# Altium schematic sheet 比较器方案.SchDoc
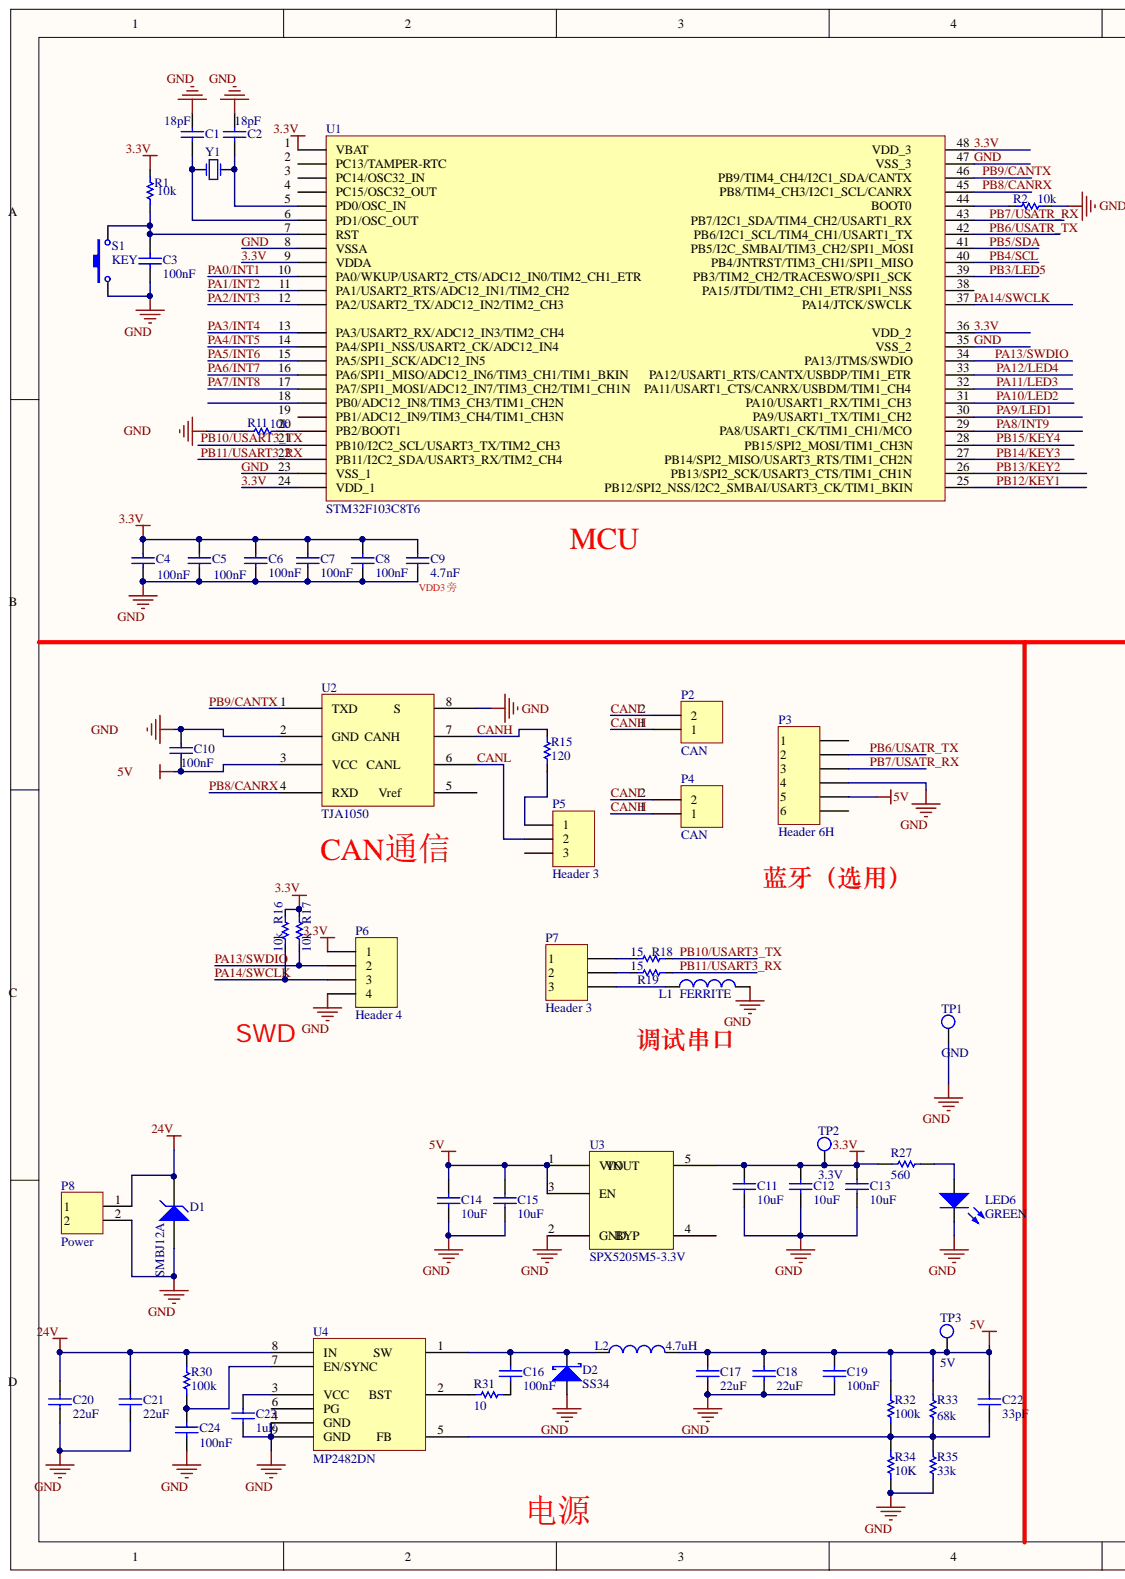
[diagram p0: 比较器方案.SchDoc, left]
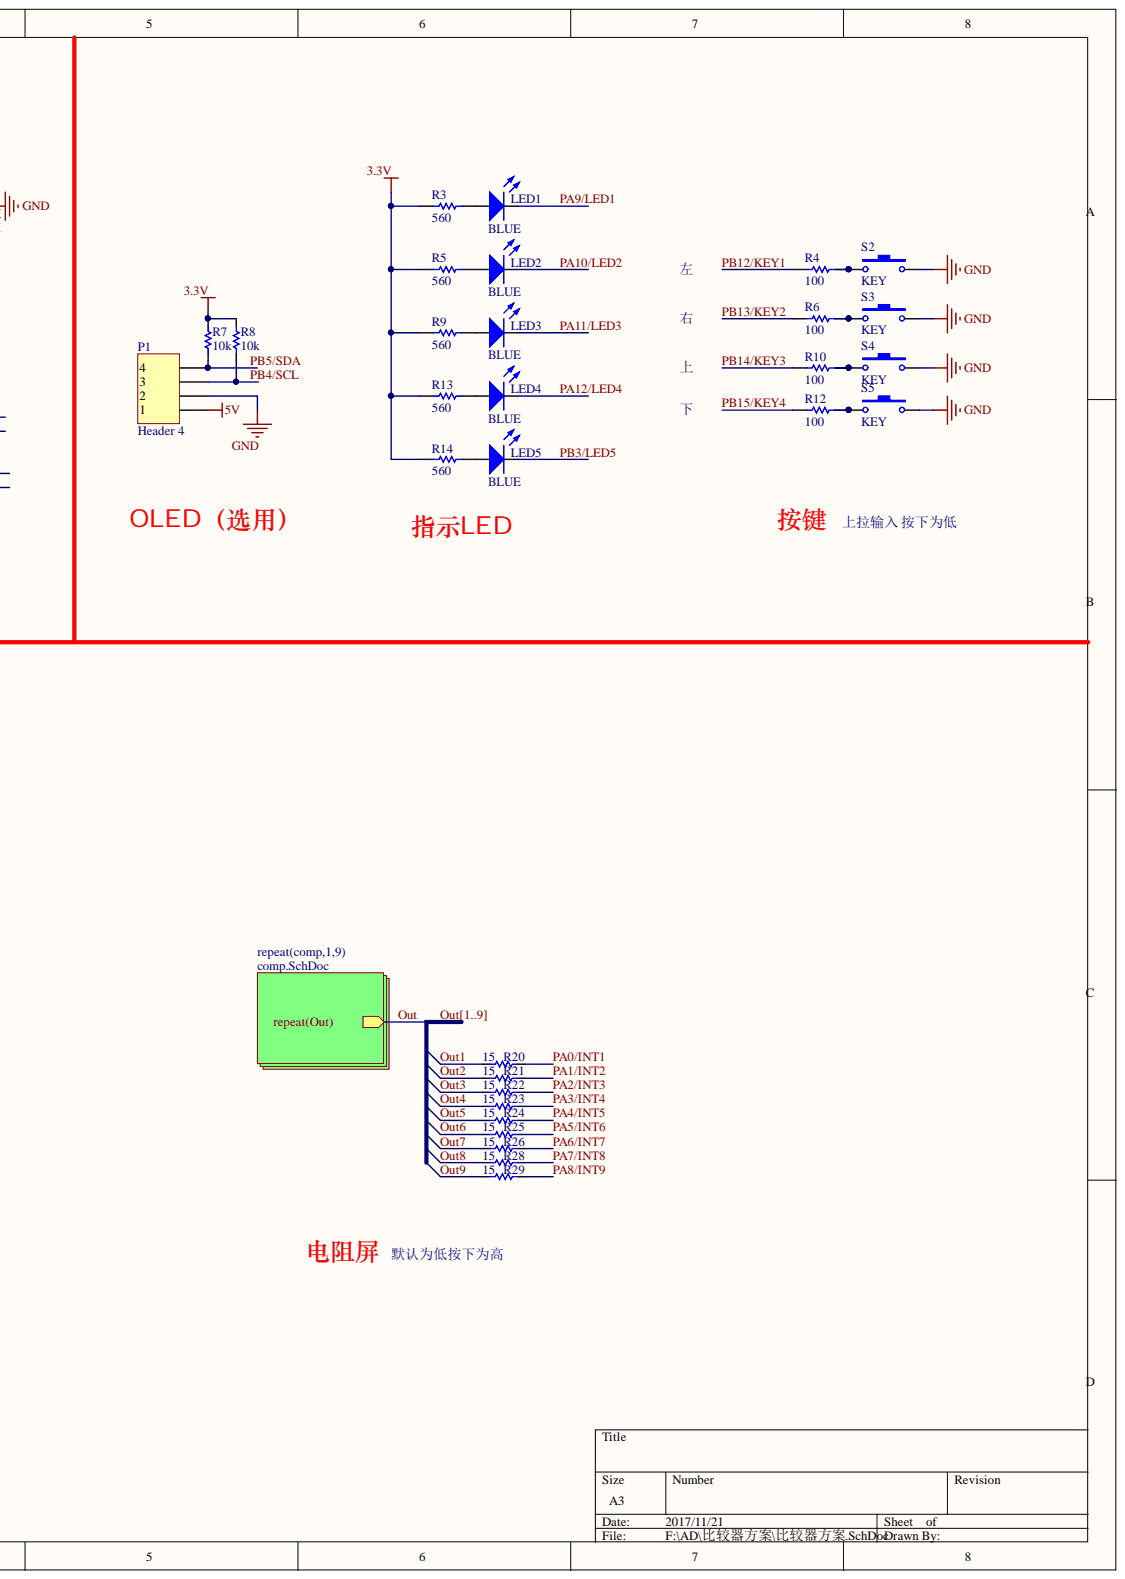
[diagram p1: 比较器方案.SchDoc, right]

## Parts
C1  18pF  [0603C]
C2  18pF  [0603C]
C3  100nF  [0603C]
C4  100nF  [0603C]
C5  100nF  [0603C]
C6  100nF  [0603C]
C7  100nF  [0603C]
C8  100nF  [0603C]
C9  4.7nF  [0603C]
C10  100nF  [0603C]
C11  value 10uF  [0603C]  (hidden comment: 1uF/0603/50V)
C12  value 10uF  [0603C]  (hidden comment: 1uF/0603/50V)
C13  value 10uF  [0603C]  (hidden comment: 1uF/0603/50V)
C14  value 10uF  [0603C]  (hidden comment: 1uF/0603/50V)
C15  value 10uF  [0603C]  (hidden comment: 1uF/0603/50V)
C16  value 100nF  [0603C]  (hidden comment: 1uF/0603/50V)
C17  value 22uF  [0805C]  (hidden comment: 10uF/0603/50V)
C18  value 22uF  [0805C]  (hidden comment: 10uF/0603/50V)
C19  value 100nF  [0603C]  (hidden comment: 1uF/0603/50V)
C20  value 22uF  [1210C]  (hidden comment: 1uF/0603/50V)
C21  value 22uF  [1210C]  (hidden comment: 1uF/0603/50V)
C22  value 33pF  [0603C]  (hidden comment: 1uF/0603/50V)
C23  value 1uF  [0603C]  (hidden comment: 1uF/0603/50V)
C24  value 100nF  [0603C]  (hidden comment: 1uF/0603/50V)
D1  SMBJ12A  [SMB/DO-214AA]
D2  SS34  [SMA/DO-214AC]
L1  FERRITE  [0603L]
L2  value 4.7uH  [6030L]  (hidden comment: 10mH/0402)
LED1  BLUE  [0603led]
LED2  BLUE  [0603led]
LED3  BLUE  [0603led]
LED4  BLUE  [0603led]
LED5  BLUE  [0603led]
LED6  GREEN  [0603led]
P1  Header 4  [OLED_I2C]
P2  CAN  [MX3.0MM 2X1AW]
P3  Header 6H  [HEADER 6x1 M]
P4  CAN  [MX3.0MM 2X1AW]
P5  Header 3  [HEADER 3x1]
P6  Header 4  [5264-AW]
P7  Header 3  [HY2.00MM 3x1]
P8  Power  [XT30PW-F]
R1  10k  [0603R]
R2  value 10k  [0603R]  (hidden comment: 1K/5%)
R3  value 560  [0603R]  (hidden comment: Res1)
R4  value 100  [0603R]  (hidden comment: Res1)
R5  value 560  [0603R]  (hidden comment: Res1)
R6  value 100  [0603R]  (hidden comment: Res1)
R7  10k  [0603R]
R8  10k  [0603R]
R9  value 560  [0603R]  (hidden comment: Res1)
R10  value 100  [0603R]  (hidden comment: Res1)
R11  value 10k  [0603R]  (hidden comment: 1K/5%)
R12  value 100  [0603R]  (hidden comment: Res1)
R13  value 560  [0603R]  (hidden comment: Res1)
R14  value 560  [0603R]  (hidden comment: Res1)
R15  value 120  [0603R]  (hidden comment: 1K/5%)
R16  10k  [0603R]
R17  10k  [0603R]
R18  15  [0603R]
R19  15  [0603R]
R20  15  [0603R]
R21  15  [0603R]
R22  15  [0603R]
R23  15  [0603R]
R24  15  [0603R]
R25  15  [0603R]
R26  15  [0603R]
R27  value 560  [0603R]  (hidden comment: Res1)
R28  15  [0603R]
R29  15  [0603R]
R30  value 100k  [0603R]  (hidden comment: Res1)
R31  10  [0603R]
R32  1%  value 100k  [0603R]
R33  value 68k  [0603R]  (hidden comment: Res1)
R34  1%  value 10K  [0603R]
R35  value 33k  [0603R]  (hidden comment: Res1)
S1  KEY  [SW-3x6mm-SMT]
S2  KEY  [SW-4.5x4.5mm-SMT]
S3  KEY  [SW-4.5x4.5mm-SMT]
S4  KEY  [SW-4.5x4.5mm-SMT]
S5  KEY  [SW-4.5x4.5mm-SMT]
TP1  GND  [TP_VIA]
TP2  3.3V  [TP_SMT]
TP3  5V  [TP_SMT]
U1  STM32F103C8T6  [LQFP_48]
U2  TJA1050  [SOIC-8]
U3  SPX5205M5-3.3V  [SOT-23-5 L]
U4  MP2482DN  [SOIC8E]
Y1  XTAL  [NX5032GA]  (value not drawn)

## Nets
5V: C10.2 C14.2 C15.2 C17.2 C18.2 C19.2 C22.1 L2.2 P1.1 P3.5 R32.2 R33.2 TP3.1 U2.3(VCC) U3.1(VIN) U3.3(EN)
24V: C20.2 C21.2 D1.2 P8.1 R30.2 U4.8(IN)
3.3V: C4.2 C5.2 C6.1 C7.1 C8.1 C9.1 C11.2 C12.2 C13.2 P6.1 R1.2 R3.2 R5.2 R7.2 R8.2 R9.2 R13.2 R14.2 R16.1 R17.1 R27.2 TP2.1 U1.1(VBAT) U1.9(VDDA) U1.24(VDD_1) U1.36 U1.48 U3.5
CANH: P2.1 P4.1 R15.2 U2.7
CANL: P2.2 P4.2 P5.2 U2.6
GND: C1.2 C2.2 C3.2 C4.1 C5.1 C6.2 C7.2 C8.2 C9.2 C10.1 C11.1 C12.1 C13.1 C14.1 C15.1 C17.1 C18.1 C19.1 C20.1 C21.1 C23.1 C24.1 D1.1 D2.1 L1.2 LED6.2 P1.2 P3.4 P6.4 P8.2 R2.2 R11.1 R34.1 R35.1 S1.1 S2.2 S3.2 S4.2 S5.2 TP1.1 U1.8(VSSA) U1.23(VSS_1) U1.35 U1.47 U2.2(GND) U2.8 U3.2(GND) U4.4(GND) U4.9(GND)
OUT1: R20.2  [also labelled OUT]
OUT2: R21.2  [also labelled OUT]
OUT3: R22.2  [also labelled OUT]
OUT4: R23.2  [also labelled OUT]
OUT5: R24.2  [also labelled OUT]
OUT6: R25.2  [also labelled OUT]
OUT7: R26.2  [also labelled OUT]
OUT8: R28.2  [also labelled OUT]
OUT9: R29.2  [also labelled OUT]
PA0/INT1: R20.1 U1.10(PA0/WKUP/USART2_CTS/ADC12_IN0/TIM2_CH1_ETR)
PA1/INT2: R21.1 U1.11(PA1/USART2_RTS/ADC12_IN1/TIM2_CH2)
PA2/INT3: R22.1 U1.12(PA2/USART2_TX/ADC12_IN2/TIM2_CH3)
PA3/INT4: R23.1 U1.13(PA3/USART2_RX/ADC12_IN3/TIM2_CH4)
PA4/INT5: R24.1 U1.14(PA4/SPI1_NSS/USART2_CK/ADC12_IN4)
PA5/INT6: R25.1 U1.15(PA5/SPI1_SCK/ADC12_IN5)
PA6/INT7: R26.1 U1.16(PA6/SPI1_MISO/ADC12_IN6/TIM3_CH1/TIM1_BKIN)
PA7/INT8: R28.1 U1.17(PA7/SPI1_MOSI/ADC12_IN7/TIM3_CH2/TIM1_CH1N)
PA8/INT9: R29.1 U1.29
PA9/LED1: LED1.2 U1.30
PA10/LED2: LED2.2 U1.31
PA11/LED3: LED3.2 U1.32
PA12/LED4: LED4.2 U1.33
PA13/SWDIO: P6.2 R17.2 U1.34
PA14/SWCLK: P6.3 R16.2 U1.37
PB3/LED5: LED5.2 U1.39
PB4/SCL: P1.3 R8.1 U1.40
PB5/SDA: P1.4 R7.1 U1.41
PB6/USATR_TX: P3.2 U1.42
PB7/USATR_RX: P3.3 U1.43
PB8/CANRX: U1.45 U2.4(RXD)
PB9/CANTX: U1.46 U2.1(TXD)
PB10/USART3_TX: R18.1 U1.21(PB10/I2C2_SCL/USART3_TX/TIM2_CH3)
PB11/USART3_RX: R19.1 U1.22(PB11/I2C2_SDA/USART3_RX/TIM2_CH4)
PB12/KEY1: R4.2 U1.25
PB13/KEY2: R6.2 U1.26
PB14/KEY3: R10.2 U1.27
PB15/KEY4: R12.2 U1.28
NetC1_1: C1.1 U1.6(PD1/OSC_OUT) Y1.1
NetC2_1: C2.1 U1.5(PD0/OSC_IN) Y1.2
NetC3_1: C3.1 R1.1 S1.2 U1.7(RST)
NetC16_1: C16.1 R31.2
NetC16_2: C16.2 D2.2 L2.1 U4.1
NetC22_2: C22.2 R32.1 R33.1 R34.2 R35.2 U4.5
NetC23_2: C23.2 U4.3(VCC)
NetC24_2: C24.2 R30.1 U4.7(EN/SYNC)
NetL1_1: L1.1 P7.3
NetLED1_1: LED1.1 R3.1
NetLED2_1: LED2.1 R5.1
NetLED3_1: LED3.1 R9.1
NetLED4_1: LED4.1 R13.1
NetLED5_1: LED5.1 R14.1
NetLED6_1: LED6.1 R27.1
NetP3_1: P3.1
NetP3_6: P3.6
NetP5_1: P5.1 R15.1
NetP5_3: P5.3
NetP7_1: P7.1 R18.2
NetP7_2: P7.2 R19.2
NetR2_1: R2.1 U1.44
NetR4_1: R4.1 S2.1
NetR6_1: R6.1 S3.1
NetR10_1: R10.1 S4.1
NetR11_2: R11.2 U1.20(PB2/BOOT1)
NetR12_1: R12.1 S5.1
NetR31_1: R31.1 U4.2
NetU1_2: U1.2(PC13/TAMPER-RTC)
NetU1_3: U1.3(PC14/OSC32_IN)
NetU1_4: U1.4(PC15/OSC32_OUT)
NetU1_18: U1.18(PB0/ADC12_IN8/TIM3_CH3/TIM1_CH2N)
NetU1_19: U1.19(PB1/ADC12_IN9/TIM3_CH4/TIM1_CH3N)
NetU1_38: U1.38
NetU2_5: U2.5
NetU3_4: U3.4
NetU4_6: U4.6(PG)

## Library data of the parts
C1-C10 = Cap: Capacitor; Value: 100pF; Component Kind: Standard; LatestRevisionDate: 17-Jul-2002; LatestRevisionNote: Re-released for DXP Platform.; Library Name: MyComponents.SchLib; PackageDescription: Radial Cap, Thru-Hole; 2 Leads; 0.3 in Pin Spacing; PackageReference: RAD-0.3; Published: 8-Jun-2000; Signal Integrity: Cap; Simulation: CAP; Publisher: Altium Limited
C11-C24 = Cap: Capacitor; Component Kind: Standard; LatestRevisionDate: 17-Jul-2002; LatestRevisionNote: Re-released for DXP Platform.; Library Name: MyComponents.IntLib; PackageDescription: Radial Cap, Thru-Hole; 2 Leads; 0.3 in Pin Spacing; PackageReference: RAD-0.3; Published: 8-Jun-2000; Signal Integrity: Cap; Simulation: CAP; Publisher: Altium Limited
D1 = D Zener: Zener Diode; Component Kind: Standard; LatestRevisionDate: 17-Jul-2002; LatestRevisionNote: Re-released for DXP Platform.; Library Name: MyComponents.IntLib; PackageDescription: Diode, Thru-Hole; 2 Leads; 0.7 in Pin Spacing; PackageReference: DIODE-0.7; Published: 8-Jun-2000; Signal Integrity: D Zener; Simulation: ZENER; Publisher: Altium Limited
D2 = D Schottky: Schottky Diode; Code_JEDEC: DO-214-AA; Component Kind: Standard; LatestRevisionDate: 17-Jul-2002; LatestRevisionNote: Re-released for DXP Platform.; Library Name: MyComponents.IntLib; PackageDescription: DO-214-AA/SMB; 2 C-Bend Leads; Body 5.3 x 3.6 mm, inc. leads (LxW); PackageReference: SMB; Published: 8-Jun-2000; Signal Integrity: D Schottky; Simulation: SKYDIODE; Publisher: Altium Limited
L1 = Inductor: Code_IEC: 1005; Value: 10uH; Code_JEITA: 0402; Component Kind: Standard; Description: Inductor; LatestRevisionDate: 29-May-2009; LatestRevisionNote: IPC-7351 Footprint Added.; Library Name: MyComponents.IntLib; PackageDescription: Chip Inductor; PackageReference: 0402-A; Published: 8-Jun-2000; Signal Integrity: Inductor; Simulation: INDUCTOR; Publisher: Altium Limited
L2 = Inductor: Code_IEC: 1005; Code_JEITA: 0402; Component Kind: Standard; Description: Inductor; LatestRevisionDate: 29-May-2009; LatestRevisionNote: IPC-7351 Footprint Added.; Library Name: MyComponents.IntLib; PackageDescription: Chip Inductor; PackageReference: 0402-A; Published: 8-Jun-2000; Signal Integrity: Inductor; Simulation: INDUCTOR; Publisher: Altium Limited
LED1-LED6 = LED: Typical RED, GREEN, YELLOW, AMBER GaAs LED; Component Kind: Standard; LatestRevisionDate: 17-Jul-2002; LatestRevisionNote: Re-released for DXP Platform.; Library Name: MyComponents.IntLib; PackageDescription: SM LED; 2 Flat Leads; PackageReference: 3.2X1.6X1.1; PackageVersion: Feb-2002; Published: 8-Jun-2000; Simulation: LED2; Publisher: Altium Limited
P1 P6 = Header 4: Header, 4-Pin; Component Kind: Standard; LatestRevisionDate: 17-Jul-2002; LatestRevisionNote: Re-released for DXP Platform.; Library Name: Miscellaneous Connectors.IntLib; Signal Integrity: Connector; Publisher: Altium Limited
P2 P4 = MX3.0_2X1: Component Kind: Standard; Library Name: MyComponents.IntLib
P3 = Header 6H: Header, 6-Pin, Right Angle; Component Kind: Standard; LatestRevisionDate: 17-Jul-2002; LatestRevisionNote: Re-released for DXP Platform.; Library Name: Miscellaneous Connectors.IntLib; Signal Integrity: Connector; Publisher: Altium Limited
P5 P7 = Header 3: Header, 3-Pin; Component Kind: Standard; LatestRevisionDate: 17-Jul-2002; LatestRevisionNote: Re-released for DXP Platform.; Library Name: Miscellaneous Connectors.IntLib; Signal Integrity: Connector; Publisher: Altium Limited
P8 = MX5.5_2X1: Component Kind: Standard; Library Name: MyComponents.IntLib
R1 R7 R8 R16-R26 R28 R29 R31 = Res1: Resistor; Value: 1K; Component Kind: Standard; LatestRevisionDate: 17-Jul-2002; LatestRevisionNote: Re-released for DXP Platform.; PackageDescription: Axial Device, Thru-Hole; 2 Leads; 0.3 in Pin Spacing; PackageReference: AXIAL-0.3; Published: 8-Jun-2000; Signal Integrity: Res; Simulation: RESISTOR; Publisher: Altium Limited
  R1 R7 R8 R16-R26 R28 R29: Library Name: MyComponents.SchLib
  R31: Library Name: MyComponents.IntLib
R2-R6 R9-R15 R27 R30 R32-R35 = Res1: Resistor; Component Kind: Standard; LatestRevisionDate: 17-Jul-2002; LatestRevisionNote: Re-released for DXP Platform.; PackageDescription: Axial Device, Thru-Hole; 2 Leads; 0.3 in Pin Spacing; PackageReference: AXIAL-0.3; Published: 8-Jun-2000; Signal Integrity: Res; Simulation: RESISTOR; Publisher: Altium Limited
  R2 R11 R15: Library Name: MyComponents.IntLib
  R3-R6 R9 R10 R12-R14 R27 R30 R32-R35: Library Name: Miscellaneous Devices.IntLib
S1-S5 = PushButton_2p: Button; Component Kind: Standard; LatestRevisionDate: 17-Jul-2002; LatestRevisionNote: Re-released for DXP Platform.; Library Name: MyComponents.IntLib; PackageDescription: Switch; 2 Leads; PackageReference: SPST-2; Published: 24-Mar-1999; Publisher: Altium Limited
TP1-TP3 = TP: Test Point; Component Kind: Standard; Library Name: MyComponents.SchLib
U4 = MP2482DN: 开关电源5A; Component Kind: Standard; Library Name: MyComponents.IntLib
Y1 = XTAL: Crystal Oscillator; Component Kind: Standard; LatestRevisionDate: 17-Jul-2002; LatestRevisionNote: Re-released for DXP Platform.; Library Name: MyComponents.SchLib; PackageDescription: Crystal, Thru-Hole; 2 Leads; Body 3.1 x 8.2 mm (Dia.xH); PackageReference: R38; Published: 8-Jun-2000; Simulation: XTAL; Publisher: Altium Limited
U1 = STM32F103C8T6: Component Kind: Standard; Library Name: MyComponents.IntLib
U2 = TJA1050: Component Kind: Standard; Library Name: MyComponents.IntLib
U3 = SPX5205M5-3.3V: Component Kind: Standard; Library Name: MyComponents.IntLib
